# Altium schematic sheet RS422.SchDoc(RS422)
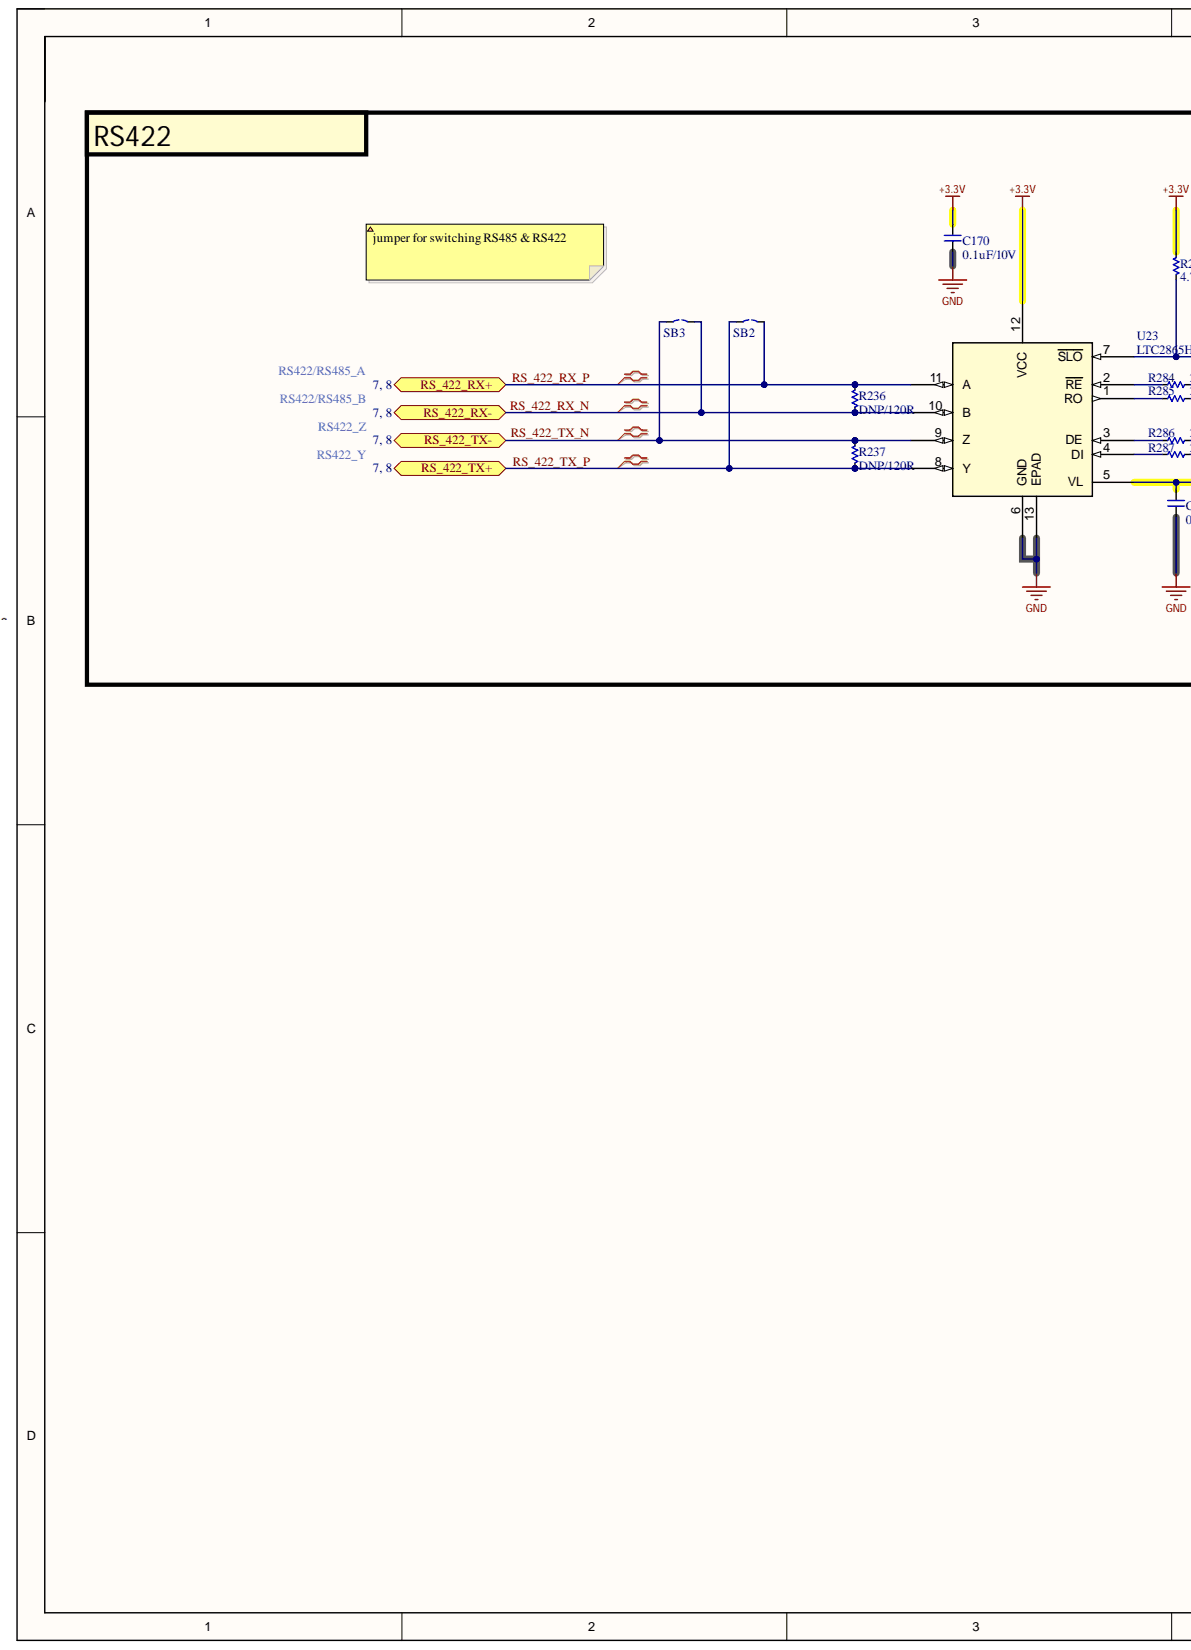
[diagram p0: RS422.SchDoc, left]
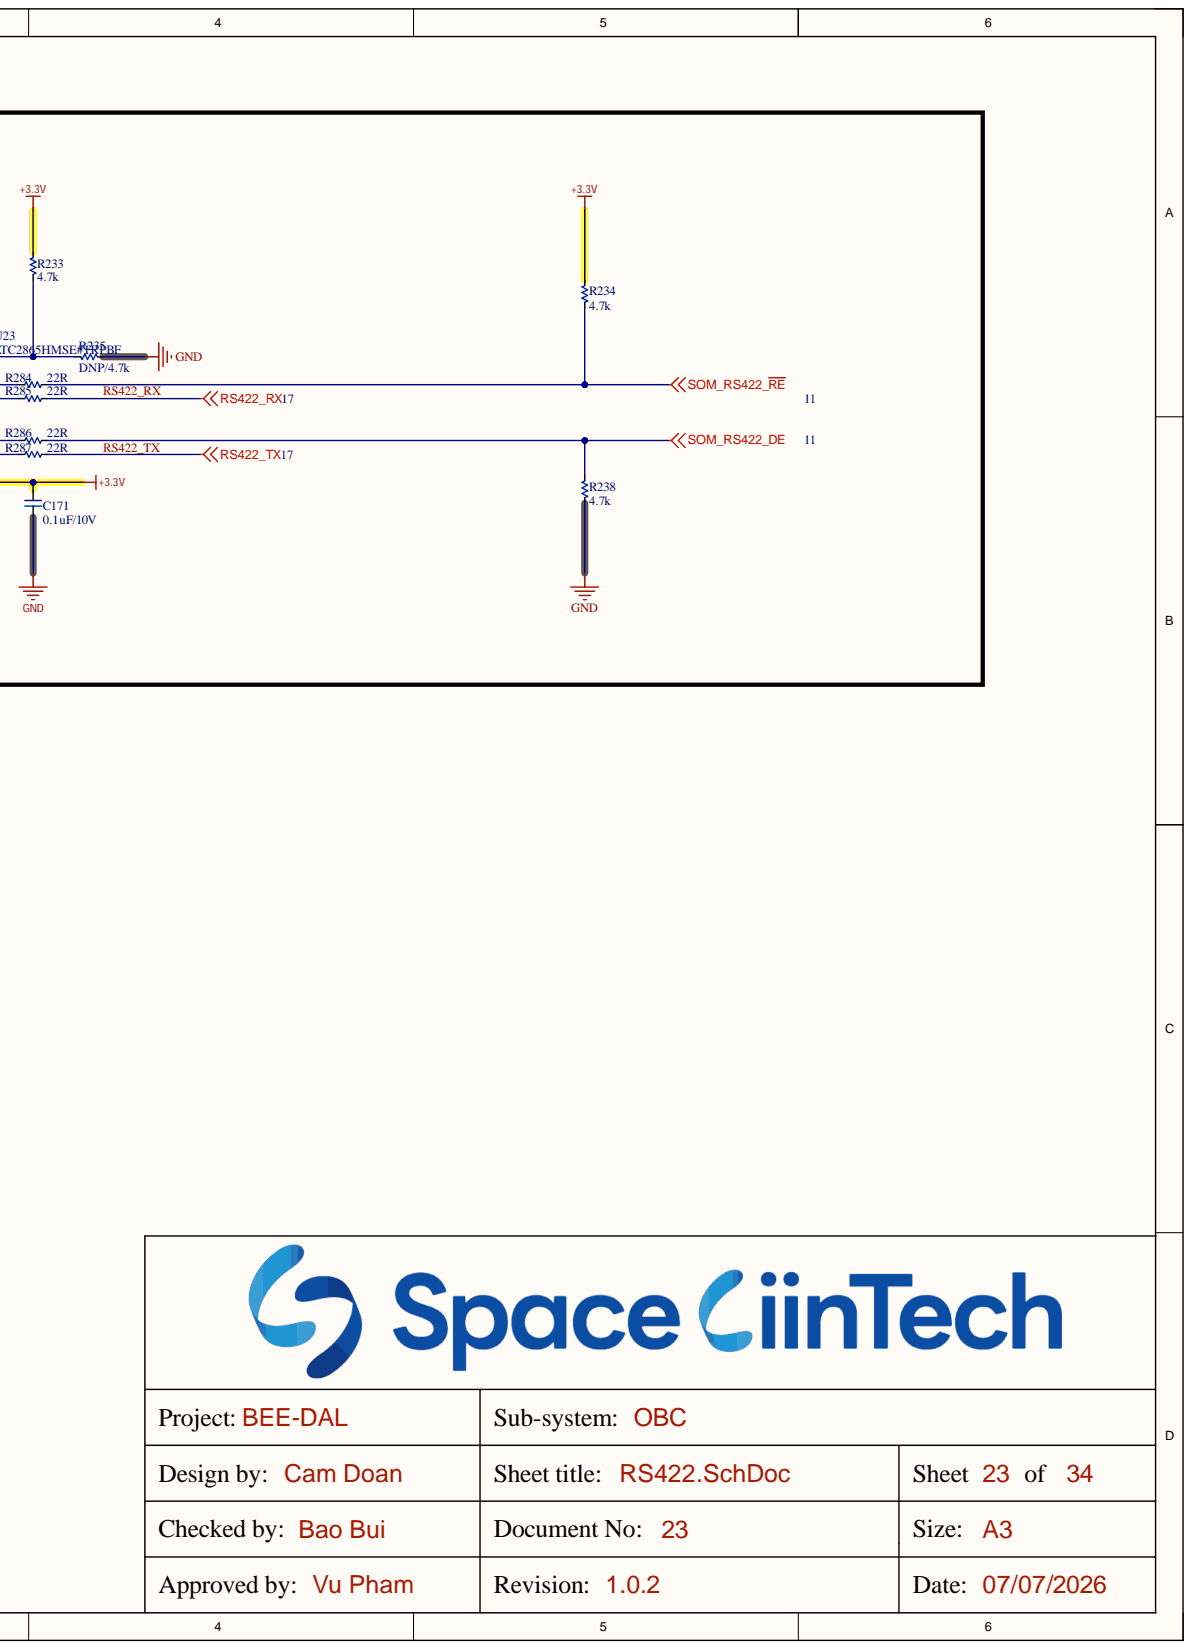
[diagram p1: RS422.SchDoc, right]

## Parts
C170  0.1uF/10V  [CAP_C_1005X50_L]
C171  0.1uF/10V  [CAP_C_1005X50_L]
R233  4.7k  [RES_C_1005x30_L]
R234  4.7k  [RES_C_1005x30_L]
R235  DNP/4.7k  [RES_C_1005x30_L]
R236  DNP/120R  [RES_C_1005x30_L]
R237  DNP/120R  [RES_C_1005x30_L]
R238  4.7k  [RES_C_1005x30_L]
R284  22R  [RES_C_1005x30_L]
R285  22R  [RES_C_1005x30_L]
R286  22R  [RES_C_1005x30_L]
R287  22R  [RES_C_1005x30_L]
SB2  JUMPER  [JUMPER_1608]  (value not drawn)
SB3  JUMPER  [JUMPER_1608]  (value not drawn)
U23  LTC2865HMSE#TRPBF  [MSOP-12_MSE_LIT]

## Ports
RS_422_RX+, RS_422_RX-, RS_422_TX+, RS_422_TX-

## Nets
+3.3V: C170.2 C171.2 R233.2 R234.2 U23.5(VL) U23.12(VCC)
GND: C170.1 C171.1 R235.2 R238.1 U23.6(GND) U23.13(EPAD)
SOM_RS422_DE: R238.2 R286.2
SOM_RS422_~{RE}: R234.1 R284.2
RS422_RX: R285.2
RS422_TX: R287.2
RS_422_RX_N: R236.2 SB3.1 U23.10(B)
RS_422_RX_P: R236.1 SB2.1 U23.11(A)
RS_422_TX_N: R237.1 SB3.2 U23.9(Z)
RS_422_TX_P: R237.2 SB2.2 U23.8(Y)
NetR233_1: R233.1 R235.1 U23.7(SLO)
NetR284_1: R284.1 U23.2(RE)
NetR285_1: R285.1 U23.1(RO)
NetR286_1: R286.1 U23.3(DE)
NetR287_1: R287.1 U23.4(DI)

## Library data of the parts
C170 C171 = _CAP_C_2: CAP; Component Kind: Standard; Library Name: LABLIB.SchLib
R233-R238 R284-R287 = _RES_B_2: RES; Component Kind: Standard
  R233-R238: Library Name: IFBschelib.SchLib
  R284-R287: Library Name: LABLIB.SchLib
U23 = LTC2865HMSETRPBF: Â±60V Fault Protected 3V to 5.5V RS485/RS422 Transceivers; Manufacturer_Name: Analog Devices Inc; Component Kind: Standard; Manufacturer_Part_Number: LTC2865HMSE#TRPBF; RefDes: RefDes; Type: Type; Copyright: Copyright (C) 2025 Ultra Librarian. All rights reserved.; Library Name: IFBschelib.SchLib
SB2 SB3 = JUMPER: Component Kind: Standard; Library Name: IFBschelib.SchLib
